# Altium schematic sheet HMI.SchDoc(HMI)
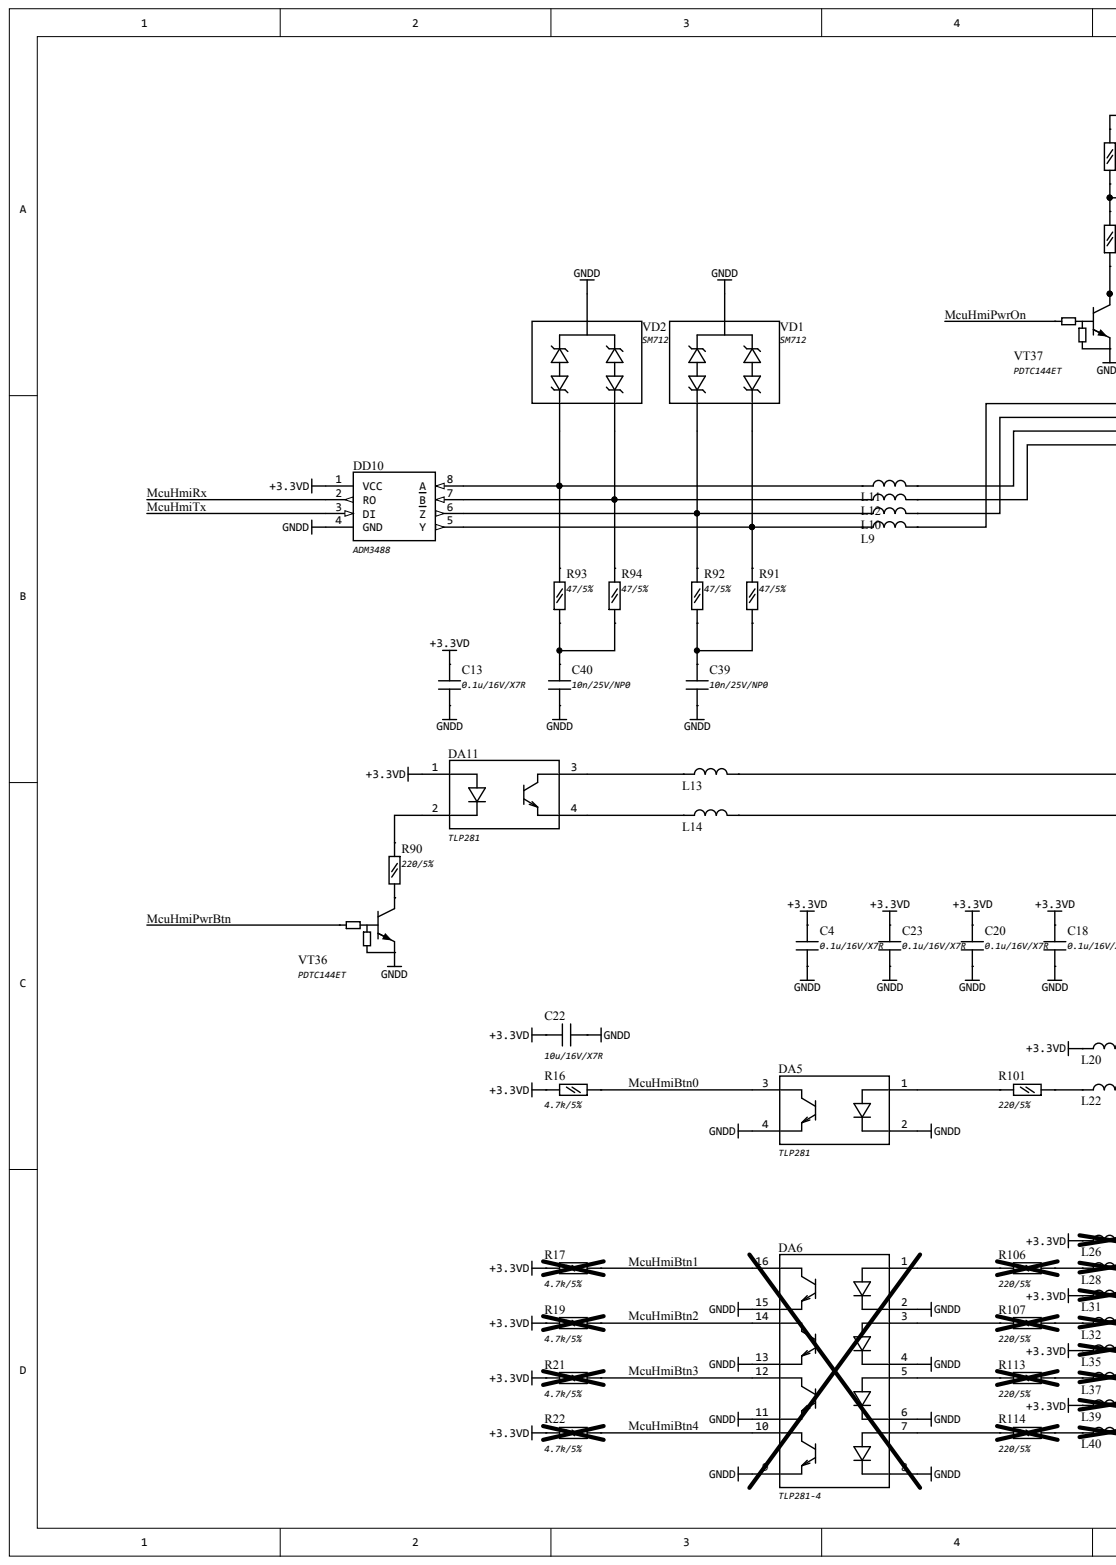
[diagram p0: HMI.SchDoc, left]
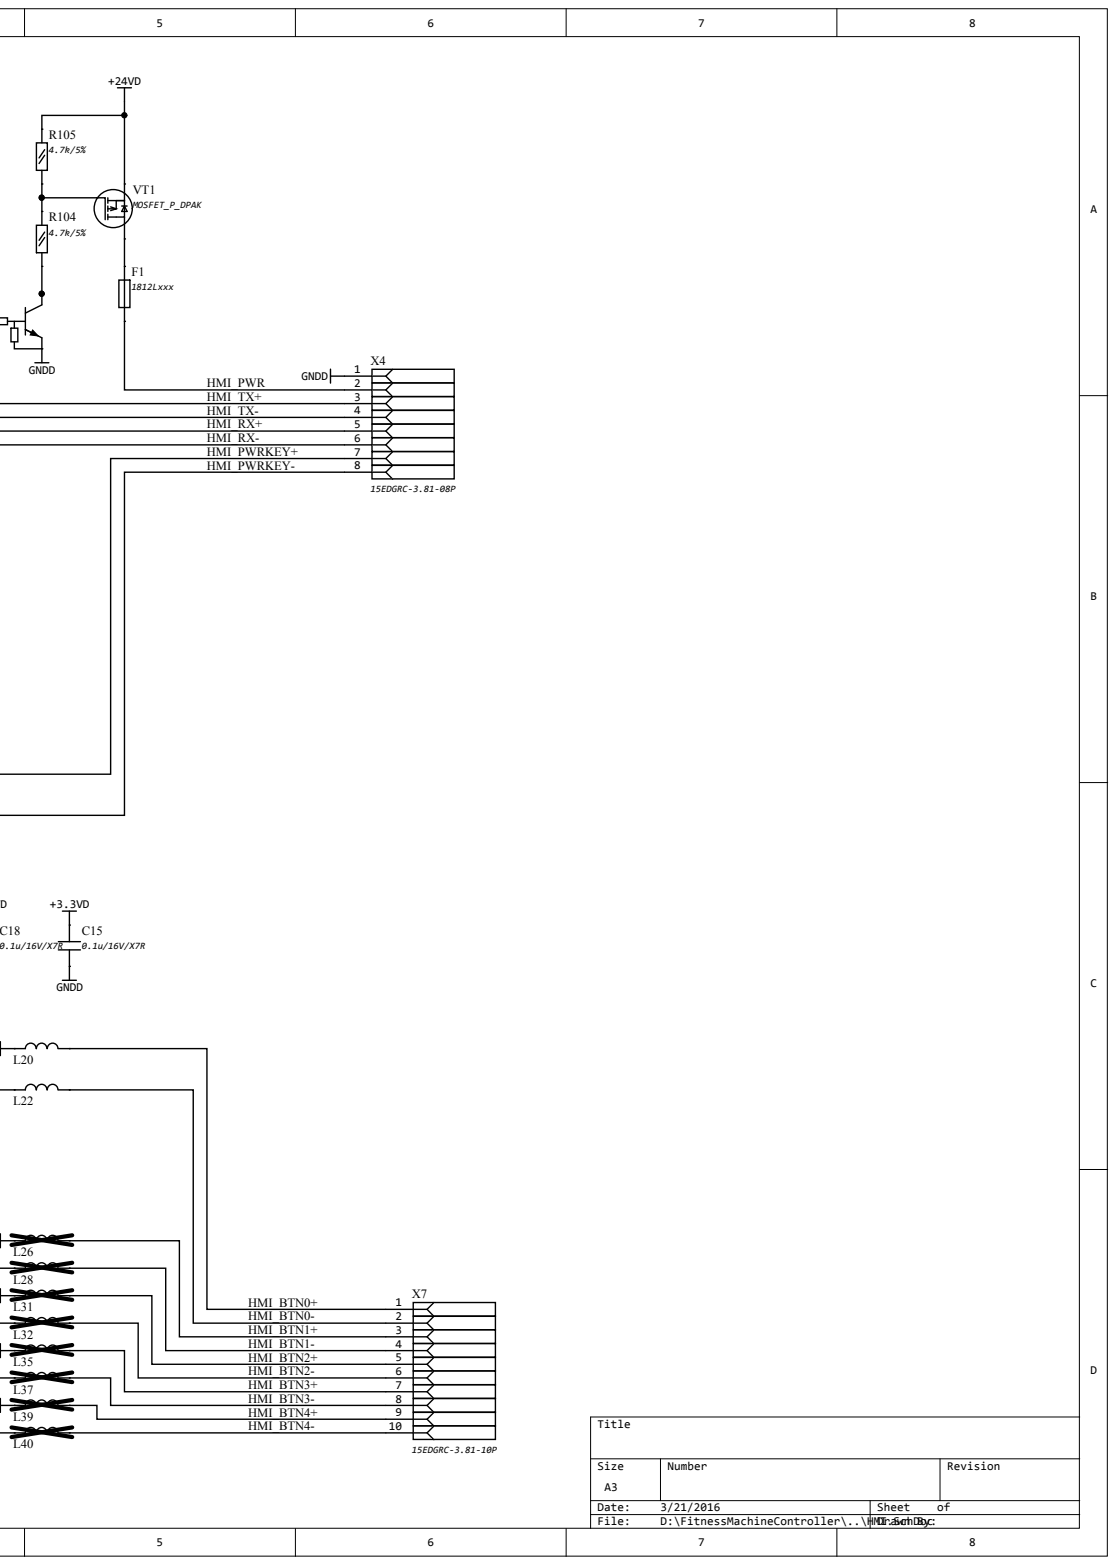
[diagram p1: HMI.SchDoc, right]

## Parts
C4  0.1u/16V/X7R
C13  0.1u/16V/X7R
C15  0.1u/16V/X7R
C18  0.1u/16V/X7R
C20  0.1u/16V/X7R
C22  10u/16V/X7R
C23  0.1u/16V/X7R
C39  10n/25V/NP0
C40  10n/25V/NP0
DA5  TLP281
DA6  TLP281-4
DA11  TLP281
DD10  ADM3488
F1  1812Lxxx
L9  FerriteFilterBLM18  (value not drawn)
L10  FerriteFilterBLM18  (value not drawn)
L11  FerriteFilterBLM18  (value not drawn)
L12  FerriteFilterBLM18  (value not drawn)
L13  FerriteFilterBLM18  (value not drawn)
L14  FerriteFilterBLM18  (value not drawn)
L20  FerriteFilterBLM18  (value not drawn)
L22  FerriteFilterBLM18  (value not drawn)
L26  FerriteFilterBLM18  (value not drawn)
L28  FerriteFilterBLM18  (value not drawn)
L31  FerriteFilterBLM18  (value not drawn)
L32  FerriteFilterBLM18  (value not drawn)
L35  FerriteFilterBLM18  (value not drawn)
L37  FerriteFilterBLM18  (value not drawn)
L39  FerriteFilterBLM18  (value not drawn)
L40  FerriteFilterBLM18  (value not drawn)
R16  4.7k/5%
R17  4.7k/5%
R19  4.7k/5%
R21  4.7k/5%
R22  4.7k/5%
R90  220/5%
R91  47/5%
R92  47/5%
R93  47/5%
R94  47/5%
R101  220/5%
R104  4.7k/5%
R105  4.7k/5%
R106  220/5%
R107  220/5%
R113  220/5%
R114  220/5%
VD1  SM712
VD2  SM712
VT1  MOSFET_P_DPAK
VT36  PDTC144ET
VT37  PDTC144ET
X4  15EDGRC-3.81-08P
X7  15EDGRC-3.81-10P

## Nets
+3.3VD: C4.2 C13.2 C15.2 C18.2 C20.2 C22.2 C23.2 DA11.1 DD10.1(VCC) L20.1 L26.1 L31.1 L35.1 L39.1 R16.1 R17.1 R19.1 R21.1 R22.1
+24VD: R105.1 VT1.2
GNDD: C4.1 C13.1 C15.1 C18.1 C20.1 C22.1 C23.1 C39.1 C40.1 DA5.2 DA5.4 DA6.2 DA6.4 DA6.6 DA6.8 DA6.9 DA6.11 DA6.13 DA6.15 DD10.4(GND) VD1.3 VD2.3 VT36.2 VT37.2 X4.1
HMI_BTN0+: L20.2 X7.1
HMI_BTN0-: L22.2 X7.2
HMI_BTN1+: L26.2 X7.3
HMI_BTN1-: L28.2 X7.4
HMI_BTN2+: L31.2 X7.5
HMI_BTN2-: L32.2 X7.6
HMI_BTN3+: L35.2 X7.7
HMI_BTN3-: L37.2 X7.8
HMI_BTN4+: L39.2 X7.9
HMI_BTN4-: L40.2 X7.10
HMI_PWR: F1.1 X4.2
HMI_PWRKEY+: L13.2 X4.7
HMI_PWRKEY-: L14.2 X4.8
HMI_RX+: L11.2 X4.5
HMI_RX-: L12.2 X4.6
HMI_TX+: L9.2 X4.3
HMI_TX-: L10.2 X4.4
MCUHMIBTN0: DA5.3 R16.2
MCUHMIBTN1: DA6.16 R17.2
MCUHMIBTN2: DA6.14 R19.2
MCUHMIBTN3: DA6.12 R21.2
MCUHMIBTN4: DA6.10 R22.2
MCUHMIPWRBTN: VT36.1
MCUHMIPWRON: VT37.1
MCUHMIRX: DD10.2(RO)
MCUHMITX: DD10.3(DI)
NetC39_2: C39.2 R91.2 R92.2
NetC40_2: C40.2 R93.2 R94.2
NetDA5_1: DA5.1 R101.1
NetDA6_1: DA6.1 R106.1
NetDA6_3: DA6.3 R107.1
NetDA6_5: DA6.5 R113.1
NetDA6_7: DA6.7 R114.1
NetDA11_2: DA11.2 R90.2
NetDA11_3: DA11.3 L13.1
NetDA11_4: DA11.4 L14.1
NetDD10_5: DD10.5(Y) L9.1 R91.1 VD1.2
NetDD10_6: DD10.6(Z) L10.1 R92.1 VD1.1
NetDD10_7: DD10.7(B) L12.1 R94.1 VD2.2
NetDD10_8: DD10.8(A) L11.1 R93.1 VD2.1
NetF1_2: F1.2 VT1.3
NetL22_1: L22.1 R101.2
NetL28_1: L28.1 R106.2
NetL32_1: L32.1 R107.2
NetL37_1: L37.1 R113.2
NetL40_1: L40.1 R114.2
NetR90_1: R90.1 VT36.3
NetR104_1: R104.1 R105.2 VT1.1
NetR104_2: R104.2 VT37.3

## Library data of the parts
C4 C13 C15 C18 C20 C23 C39 C40 = (no symbol name): ComponentDescriptionRUS: Конденсатор SMD 0603; Analog: нет; NumberSolderPointsSMD: 0; NumberSolderPointsTHT: 0
C22 = (no symbol name): ComponentDescriptionRUS: Конденсатор SMD 1206; Analog: нет; NumberSolderPointsSMD: 0; NumberSolderPointsTHT: 0
DA5 DA11 = (no symbol name): ComponentDescriptionRUS: Оптрон; Аналог: TLP291
DA6 = (no symbol name): ComponentDescriptionRUS: Оптрон; Аналог: TLP291-4
DD10 = (no symbol name): ComponentDescriptionRUS: Микросхема SO8; Аналог: нет; NumberSolderPointsSMD: 0; NumberSolderPointsTHT: 0
F1 = (no symbol name): ComponentDescriptionRUS: Предохранитель; Analog: нет; NumberSolderPointsSMD: 0; NumberSolderPointsTHT: 0
L9-L14 L20 L22 L26 L28 L31 L32 L35 L37 L39 L40 = (no symbol name): ComponentDescriptionRUS: Фильтр SMD 0603; Analog: нет; NumberSolderPointsSMD: 0; NumberSolderPointsTHT: 0
R16 R17 R19 R21 R22 R90-R94 R101 R104-R107 R113 R114 = (no symbol name): ComponentDescriptionRUS: Резистор SMD 0603; Analog: нет; NumberSolderPointsSMD: 0; NumberSolderPointsTHT: 0
VD1 VD2 = (no symbol name): Описание: Диод SMB; NumberSolderPointsSMD: 0; Аналог: нет; NumberSolderPointsTHT: 0
VT1 = (no symbol name): ComponentDescriptionRUS: Транзистор MOSFET P-канальный; Analog: нет; NumberSolderPointsSMD: 0; NumberSolderPointsTHT: 0
VT36 VT37 = (no symbol name): ComponentDescriptionRUS: Транзистор SOT23; Analog: нет; NumberSolderPointsSMD: 0; NumberSolderPointsTHT: 0
X4 = (no symbol name): Аналог: ECH381R-08P; NumberSolderPointsSMD: 0; Комплект: EC381V-08P, 15EDGK-3.81-08; NumberSolderPointsTHT: 0
X7 = (no symbol name): Аналог: ECH381R-10P; Описание: Клеммник разъемный 3.81мм; NumberSolderPointsSMD: 0; Комплект: EC381V-10P, 15EDGK-3.81-10; NumberSolderPointsTHT: 0
